# Altium schematic sheet Communication_Debug.SchDoc(Communication_Debug)
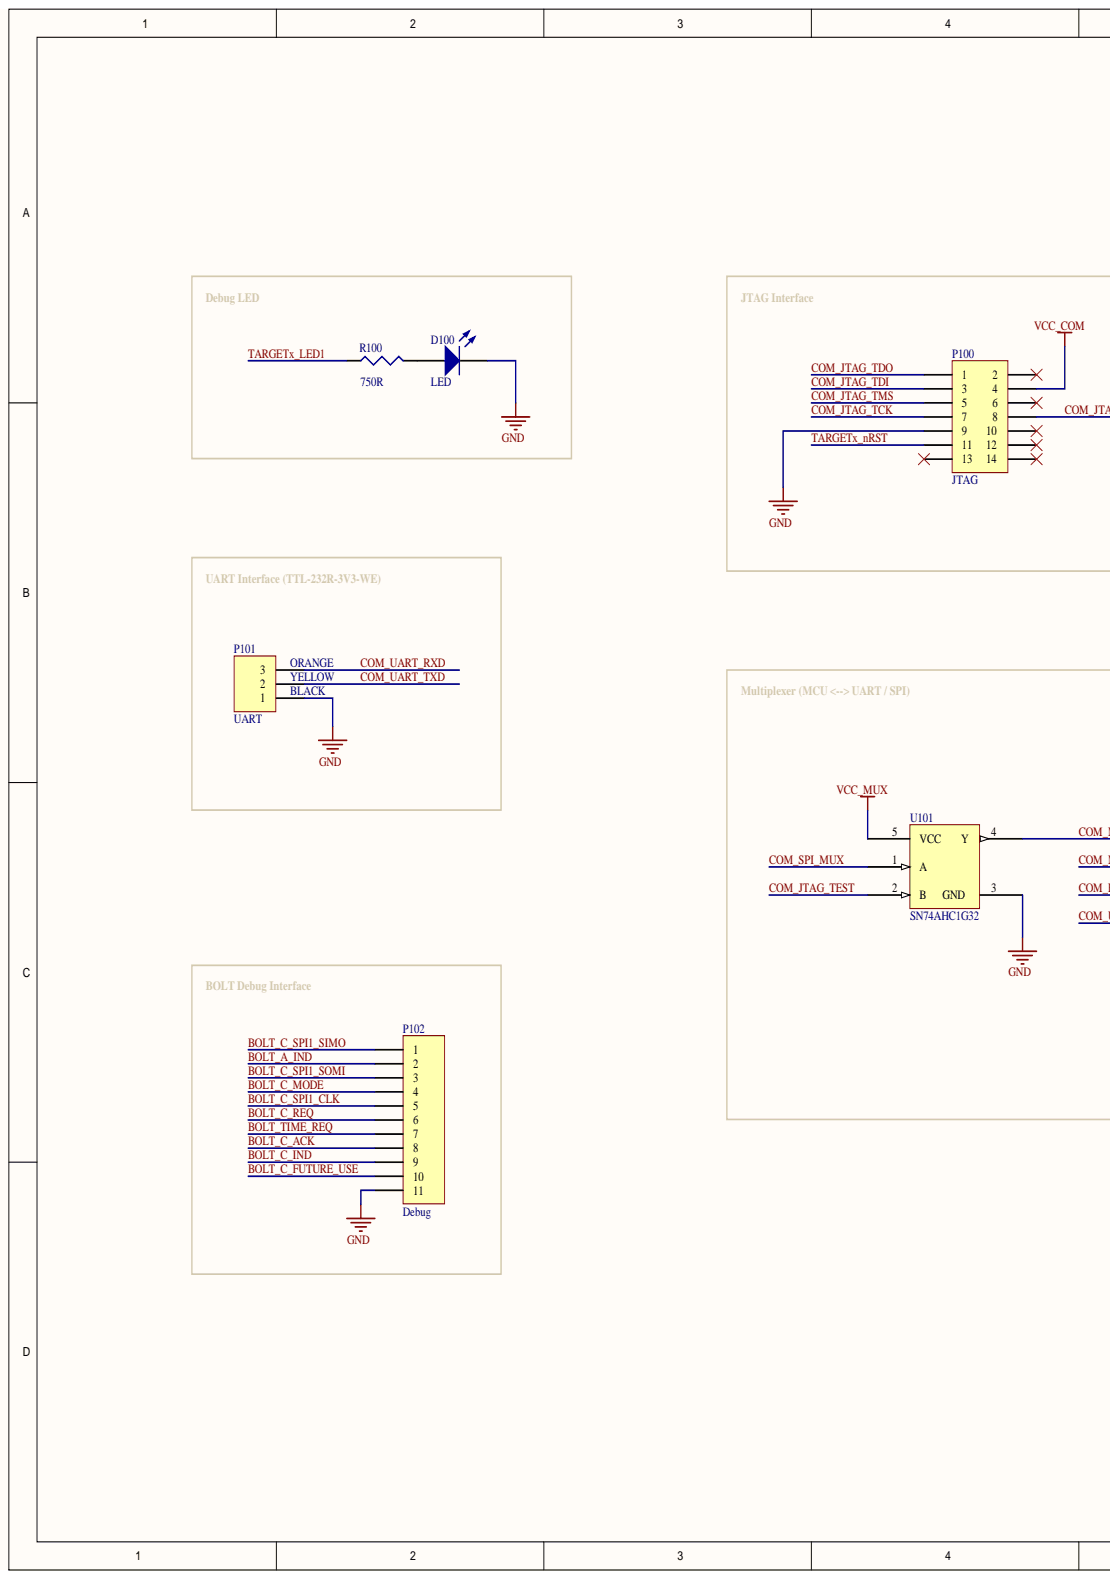
[diagram p0: Communication_Debug.SchDoc, left]
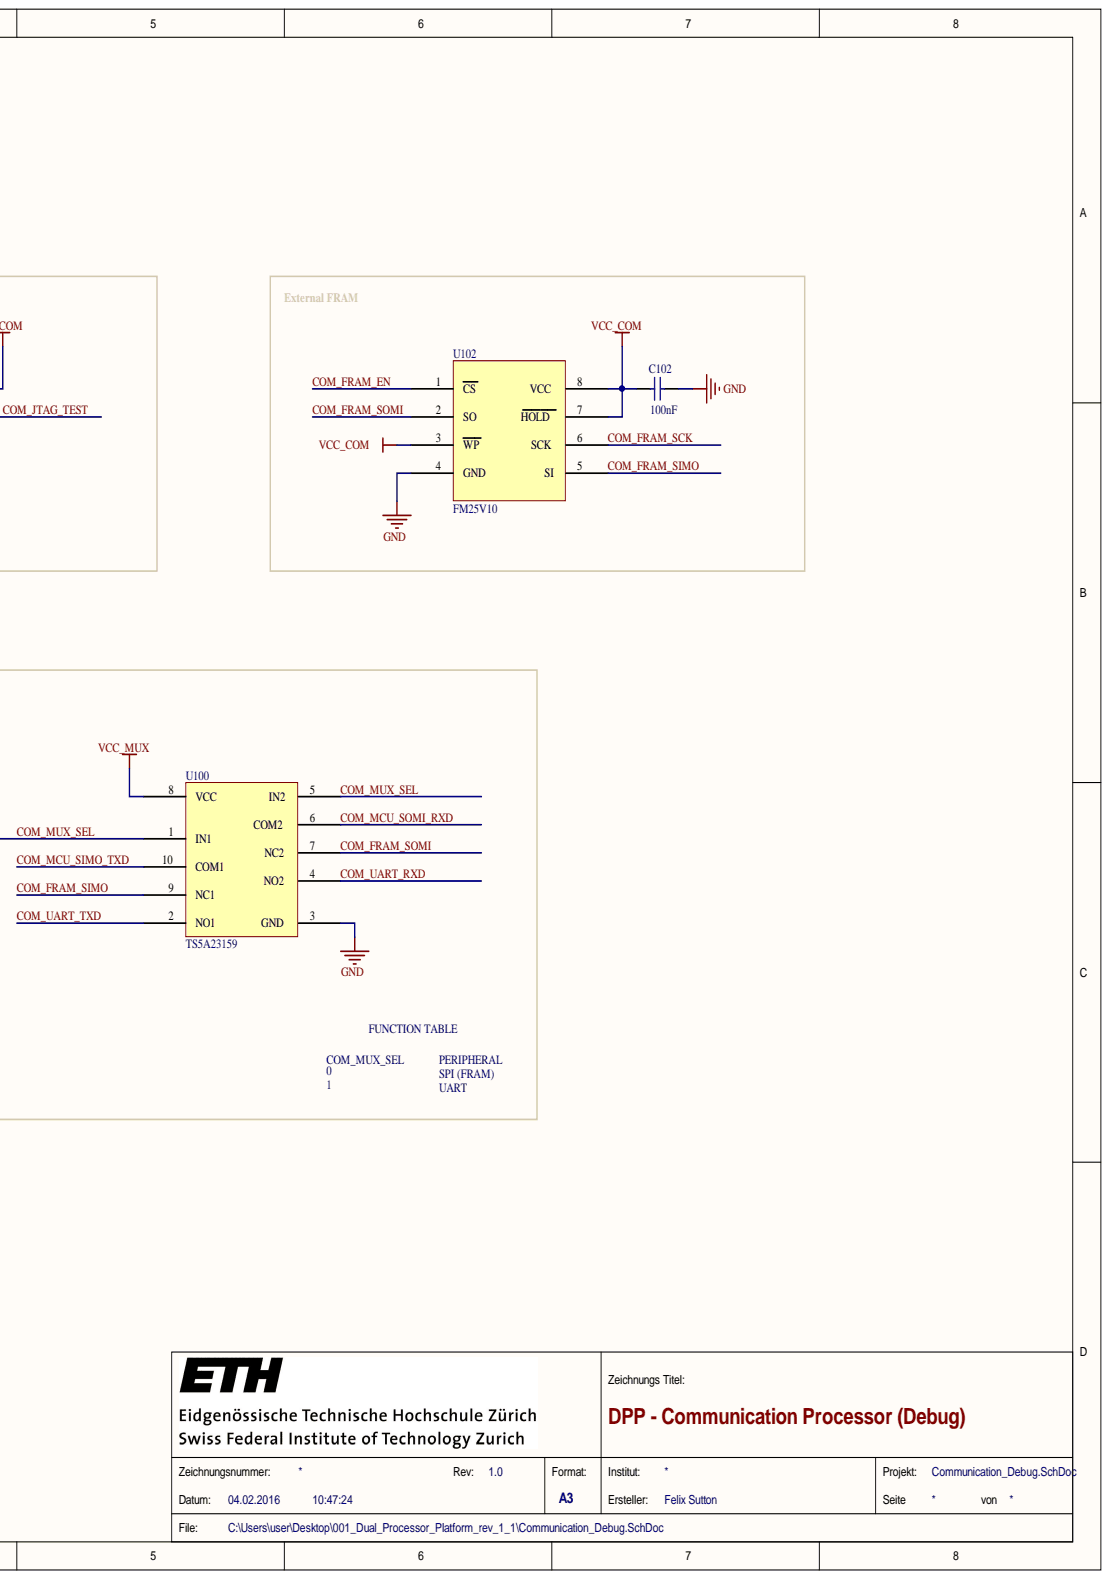
[diagram p1: Communication_Debug.SchDoc, right]

## Parts
C102  100nF  [CAPC1608N]
D100  LED  [CD2012-0805]
P100  JTAG  [HDR2X7]
P101  UART  [HDR1X3]
P102  Debug  [HDR1X11]
R100  750R  [RESC1608N]
U100  TS5A23159  [PUQFN_N10]
U101  SN74AHC1G32  [SC70-5]
U102  FM25V10  [SOIC8_MS_012]

## Nets
GND: C102.1 D100.2 P100.9 P101.1 P102.11 U100.3(GND) U101.3(GND) U102.4(GND)
VCC_COM: C102.2 P100.4 U102.3(WP) U102.7(HOLD) U102.8(VCC)
VCC_MUX: U100.8(VCC) U101.5(VCC)
BOLT_A_IND: P102.2
BOLT_C_ACK: P102.8
BOLT_C_FUTURE_USE: P102.10
BOLT_C_IND: P102.9
BOLT_C_MODE: P102.4
BOLT_C_REQ: P102.6
BOLT_C_SPI1_CLK: P102.5
BOLT_C_SPI1_SIMO: P102.1
BOLT_C_SPI1_SOMI: P102.3
BOLT_TIME_REQ: P102.7
COM_FRAM_EN: U102.1(CS)
COM_FRAM_SCK: U102.6(SCK)
COM_FRAM_SIMO: U100.9(NC1) U102.5(SI)
COM_FRAM_SOMI: U100.7(NC2) U102.2(SO)
COM_JTAG_TCK: P100.7
COM_JTAG_TDI: P100.3
COM_JTAG_TDO: P100.1
COM_JTAG_TEST: P100.8 U101.2(B)
COM_JTAG_TMS: P100.5
COM_MCU_SIMO_TXD: U100.10(COM1)
COM_MCU_SOMI_RXD: U100.6(COM2)
COM_MUX_SEL: U100.1(IN1) U100.5(IN2) U101.4(Y)
COM_SPI_MUX: U101.1(A)
COM_UART_RXD: P101.3 U100.4(NO2)
COM_UART_TXD: P101.2 U100.2(NO1)
TARGETX_LED1: R100.1
TARGETX_NRST: P100.11
NetD100_1: D100.1 R100.2

Not connected: P100 2 6 10 12 13 14

## Library data of the parts
C102 = Cap: Capacitor, Ceramic; Component Kind: Standard; LatestRevisionDate: 17-Jul-2002; LatestRevisionNote: Re-released for DXP Platform.; PackageDescription: Radial Cap, Thru-Hole; 2 Leads; 0.3 in Pin Spacing; PackageReference: RAD-0.3; Published: 8-Jun-2000; Signal Integrity: Cap; Simulation: CAP; Library Name: PermaSense.SchLib; Publisher: Altium Limited
D100 = LED0: Typical INFRARED GaAs LED; Component Kind: Standard; LatestRevisionDate: 08-Jul-2005; LatestRevisionNote: Mech Layer 1 removed.; Library Name: Miscellaneous Devices.IntLib; PackageReference: LED-0; Published: 8-Jun-2000; Publisher: Altium Limited
P100 = Header 7X2: Header, 7-Pin, Dual row; Component Kind: Standard; LatestRevisionDate: 17-Jul-2002; LatestRevisionNote: Re-released for DXP Platform.; Library Name: Miscellaneous Connectors.IntLib; Signal Integrity: Connector; Publisher: Altium Limited
P101 = Header 3: Header, 3-Pin; Component Kind: Standard; LatestRevisionDate: 17-Jul-2002; LatestRevisionNote: Re-released for DXP Platform.; Library Name: Miscellaneous Connectors.IntLib; Signal Integrity: Connector; Publisher: Altium Limited
P102 = Header 11: Header, 11-Pin; Component Kind: Standard; LatestRevisionDate: 17-Jul-2002; LatestRevisionNote: Re-released for DXP Platform.; Library Name: Miscellaneous Connectors.IntLib; Signal Integrity: Connector; Publisher: Altium Limited
R100 = Res3: Resistor; Component Kind: Standard; LatestRevisionDate: 29-May-2009; LatestRevisionNote: IPC-7351 Footprint Added.; Library Name: Miscellaneous Devices.IntLib; PackageDescription: Chip Resistor; PackageReference: J1-0603; Published: 8-Jun-2000; Publisher: Altium Limited
U100 = TS5A23159: TI Dual SPDT Analog Switch; Component Kind: Standard; Library Name: Felix_Component_Library.SchLib
U101 = SN74AHC1G08: Single 2-Input OR Gate; Component Kind: Standard; Library Name: PermaSense.SchLib
U102 = FM25V10: Cypress 1Mbit Serial FRAM; Component Kind: Standard; Library Name: Felix_Component_Library.SchLib
